# Altium schematic sheet NeuroBB.SchDoc
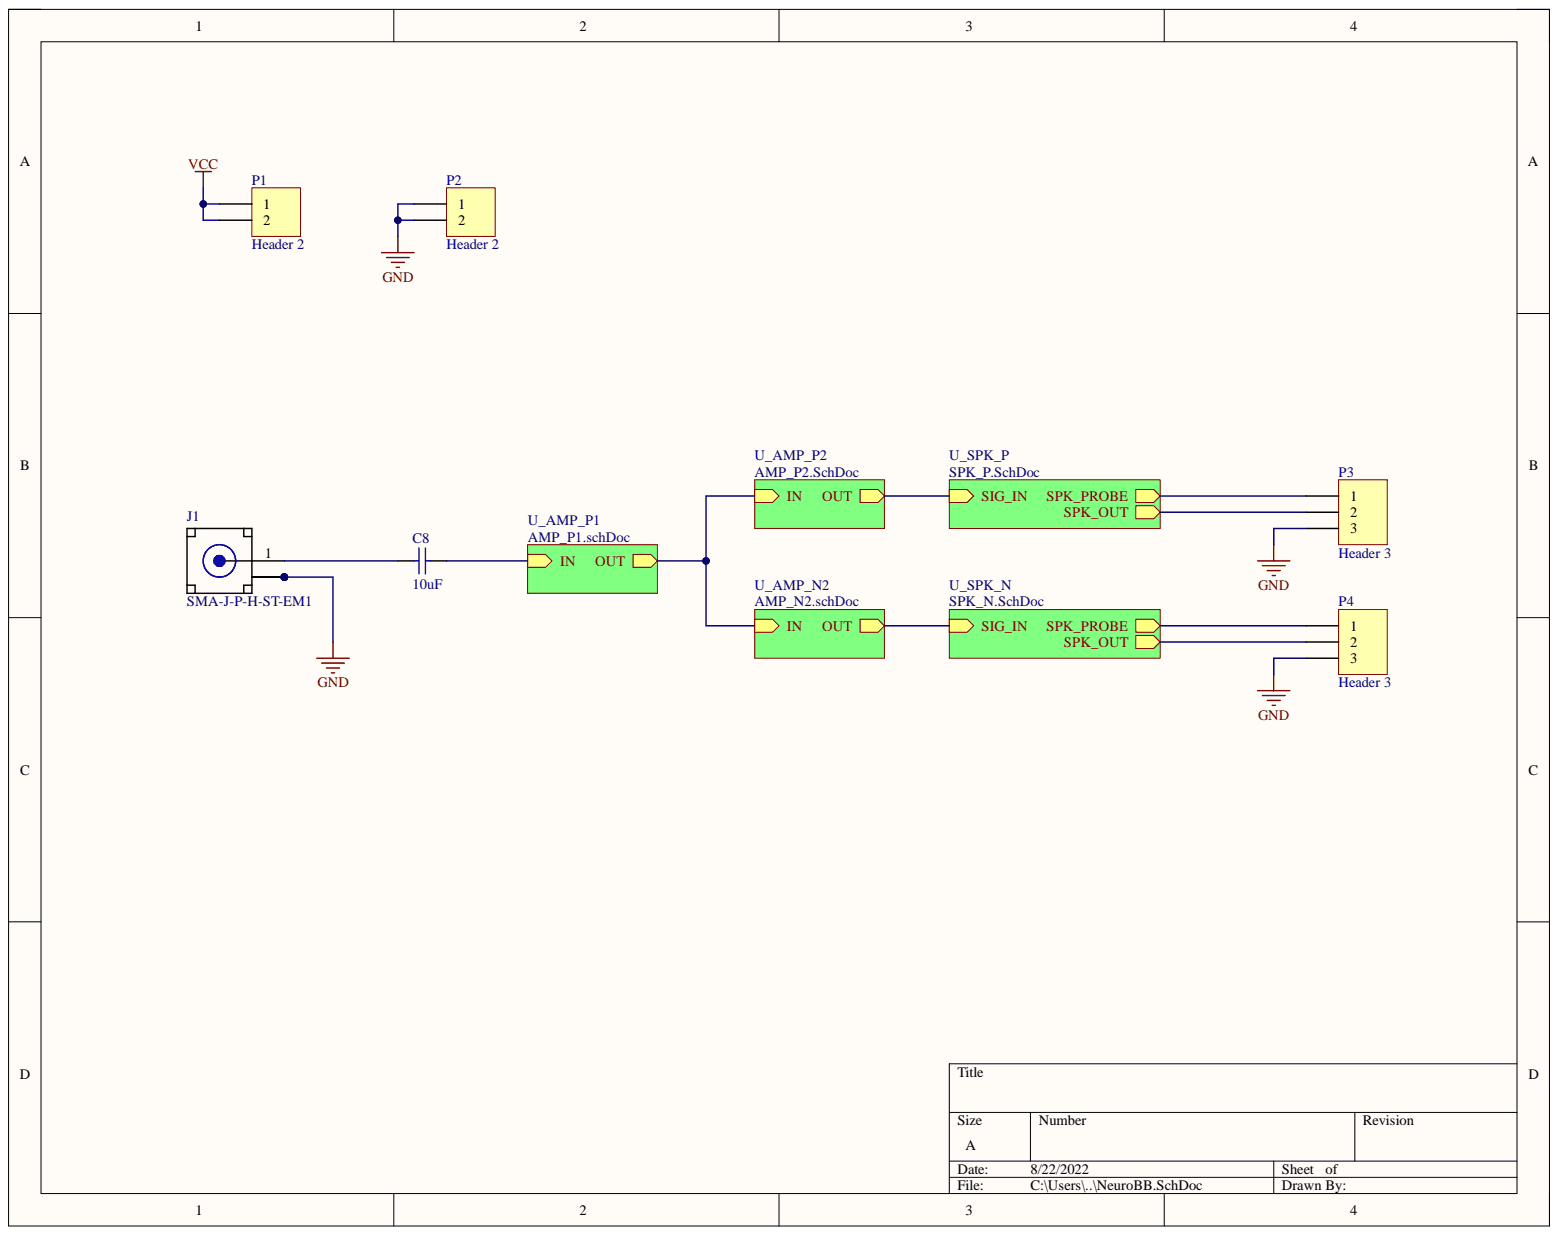
[diagram p0: NeuroBB.SchDoc]

## Parts
C8  10uF  [SMD-0603]
J1  SMA-J-P-H-ST-EM1  [SMA-J-P-H-ST-EM1]
P1  Header 2  [HDR1X2]
P2  Header 2  [HDR1X2]
P3  Header 3  [HDR1X3]
P4  Header 3  [HDR1X3]

## Nets
GND: J1.2_1 J1.2_2 J1.3_1 J1.3_2 P2.1 P2.2 P3.3 P4.3
VCC: P1.1 P1.2
NetC8_1: C8.1 J1.1
NetC8_2: C8.2
NetP3_1: P3.1
NetP3_2: P3.2
NetP4_1: P4.1
NetP4_2: P4.2
IN:
OUT:

## Library data of the parts
C8 = CAP0603: Ceramic Capacitor 0603; Component Kind: Standard; Library Name: LCR.SchLib
J1 = SMA-J-P-H-ST-EM1: Connector SMA F 50Ohm Solder ST Edge Mount Gold Over Nickel Tray; MF: Samtec; Availability: Unavailable; Component Kind: Standard; Library Name: Connectors.SchLib; MP: SMA-J-P-H-ST-EM1; Package: None; Price: None; SnapEDA_Link: https://www.snapeda.com/parts/SMA-J-P-H-ST-EM1/Samtec%20Inc./view-part/?ref=snap
P1 P2 = Header 2: Header, 2-Pin; Component Kind: Standard; LatestRevisionDate: 17-Jul-2002; LatestRevisionNote: Re-released for DXP Platform.; Library Name: Miscellaneous Connectors.IntLib; Signal Integrity: Connector; Publisher: Altium Limited
P3 P4 = Header 3: Header, 3-Pin; Component Kind: Standard; LatestRevisionDate: 17-Jul-2002; LatestRevisionNote: Re-released for DXP Platform.; Library Name: Miscellaneous Connectors.IntLib; Signal Integrity: Connector; Publisher: Altium Limited
